# Altium schematic sheet 8V_SCAB.SchDoc
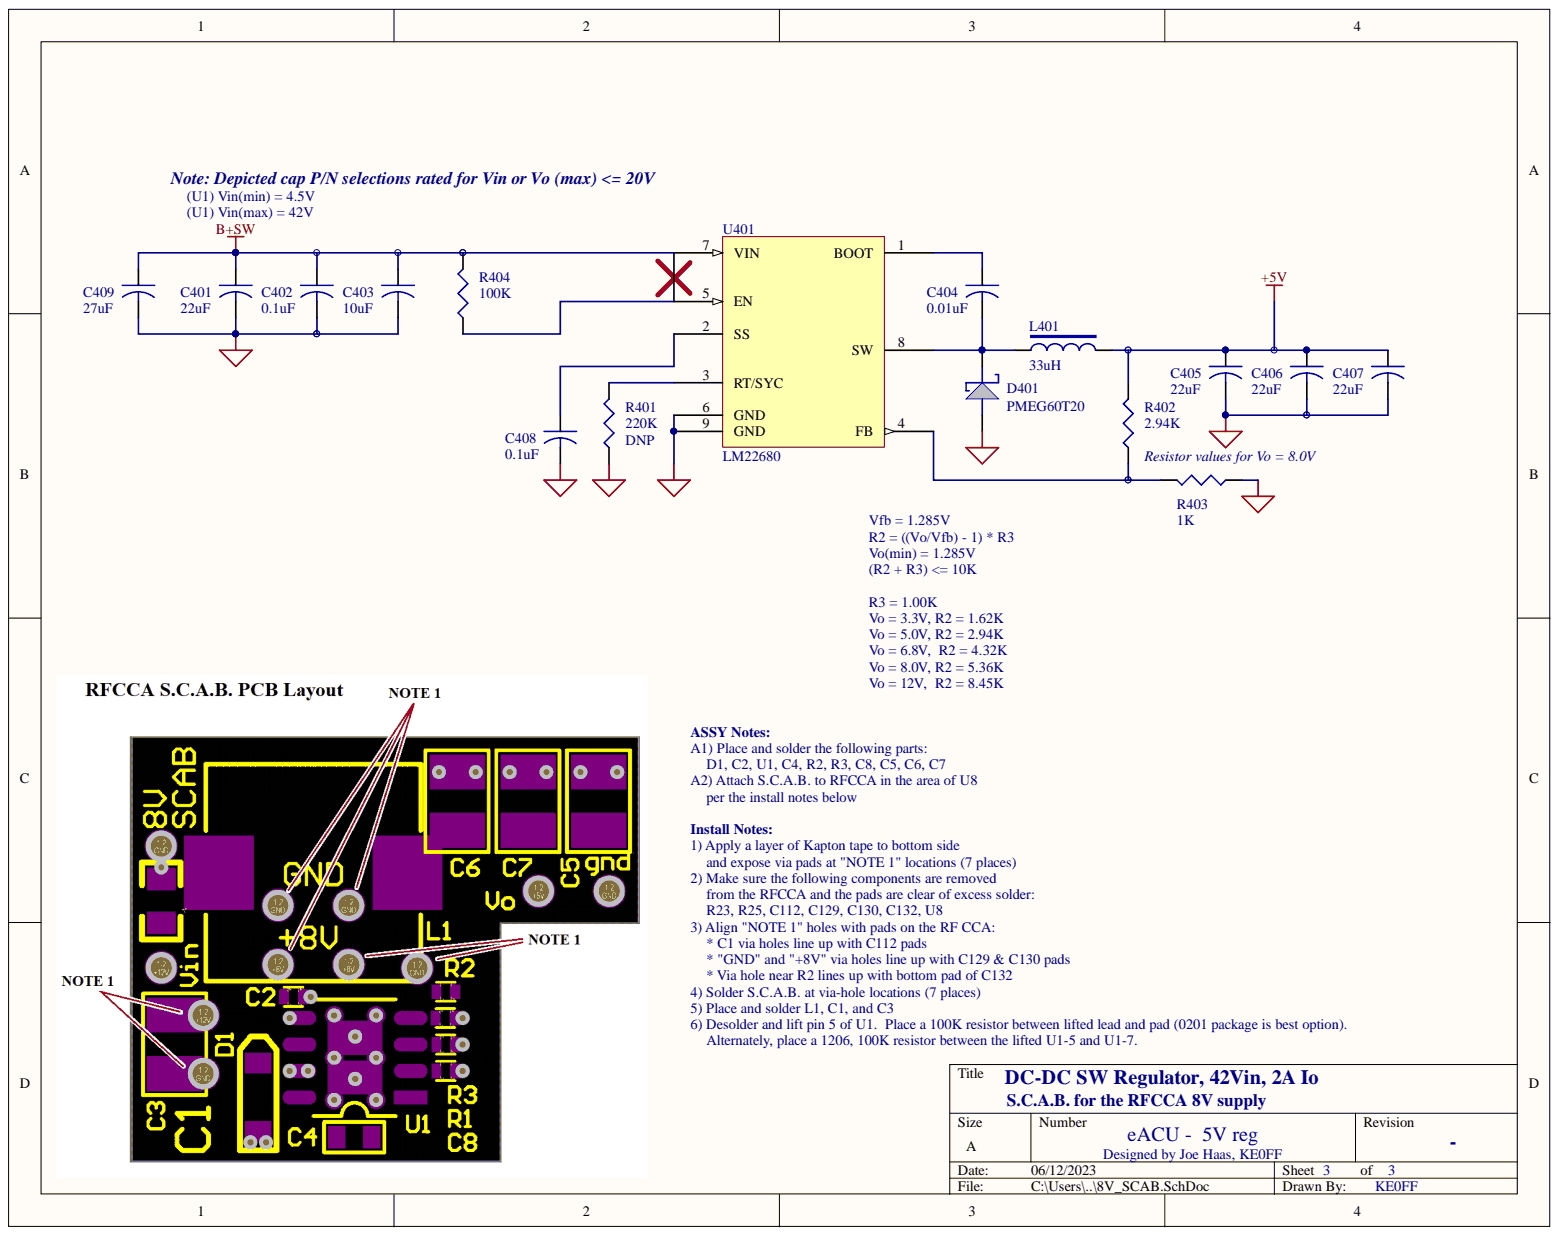
[diagram p0: 8V_SCAB.SchDoc]

## Parts
C401  22uF  [1210]
C402  0.1uF  [0402]
C403  10uF  [0805]
C404  0.01uF  [0603]
C405  22uF  [1210]
C406  22uF  [1210]
C407  22uF  [1210]
C408  0.1uF  [0402]
C409  27uF  [1210]
D401  PMEG60T20  [SOD123]
L401  33uH  [IND_PA4342]
R401  220K  [0402]
R402  2.94K  [0402]
R403  1K  [0402]
R404  100K  [0402]
U401  LM22680  [SO8-EP2]

## Nets
+5V: C405.1 C406.1 C407.1 L401.2 R402.2
B+SW: C401.1 C402.1 C403.1 C409.1 R404.2 U401.7(VIN)
GND: C401.2 C402.2 C403.2 C405.2 C406.2 C407.2 C408.2 C409.2 D401.2 R401.1 R403.1 U401.6(GND) U401.9(GND)
NetC404_1: C404.1 U401.1(BOOT)
NetC404_2: C404.2 D401.1 L401.1 U401.8(SW)
NetC408_1: C408.1 U401.2(SS)
NetR401_2: R401.2 U401.3(RT/SYC)
NetR402_1: R402.1 R403.2 U401.4(FB)
NetR404_1: R404.1 U401.5(EN)

## Library data of the parts
C401 C405-C407 C409 = CAP 0805: CAP, MLCC, X7R, 25V, 1210, 22uF; MFR: Samsung; MPN: CL32B226KAJNNNE; Component Kind: Standard; VOLTAGE: 25V
C402 C408 = CAP 0805: CAP, MLCC, X7R, 50V, 0402, 0.1uF; MFR: Murata; MPN: GRM155R71H104KE14J; Component Kind: Standard; VOLTAGE: 50V
C403 = CAP 0805: CAP, MLCC, X7R, 25V, 0805, 10uF; MFR: Samsung; MPN: CL21B106KAYQNNE; Component Kind: Standard; VOLTAGE: 25V
C404 = CAP 0805: CAP, MLCC, X7R, 50V, 0603, 0.01uF; MFR: AVX; MPN: 06035C103MAT2A; Component Kind: Standard; VOLTAGE: 50V
D401 = DIODE SCHOTTKY: DIO, SHKY, 2A, 40V, SOD-123; MFR: Nexperia; MPN: PMEG60T20ELRX; Component Kind: Standard; Library Name: Galaxy SCH-jem-1.08.SchLib
L401 = INDUCTOR2: IND, SMD, 33uH, 3A, 0.91DCR; MFR: Pulse; MPN: PA4342.333ANLT; Component Kind: Standard; Library Name: Galaxy SCH-jem-1.08.SchLib
R401 = R0805: RES, CHP, 1%, 0402, 220K; MFR: Yageo; MPN: RC0402FR-07220KL; Component Kind: Standard; DNP: DNP
R402 = R0805: RES, 0402, 1%, 5.36K; MFR: Yageo; MPN: RC0402FR-075K36L; Component Kind: Standard
R403 = R0805: RES, 0402, 1%, 1K; MFR: Yageo; MPN: RC0402FR-071KL; Component Kind: Standard
R404 = R0805: RES, 0201, 1%, 100K; MFR: Yageo; MPN: RC0201FR-07100KL; Component Kind: Standard
U401 = LM22680: IC, VREG, BUCK-SW, SO8; MFR: TI; MPN: LM22680MRX-ADJ/NOPB; MPN_ALT: LM22680QMRE-ADJ/NOPB; Component Kind: Standard; MFR_ALT: TI; Library Name: Galaxy SCH-jem-1.08.SchLib
